# Altium schematic sheet 5-W5500.SchDoc(5-W5500)
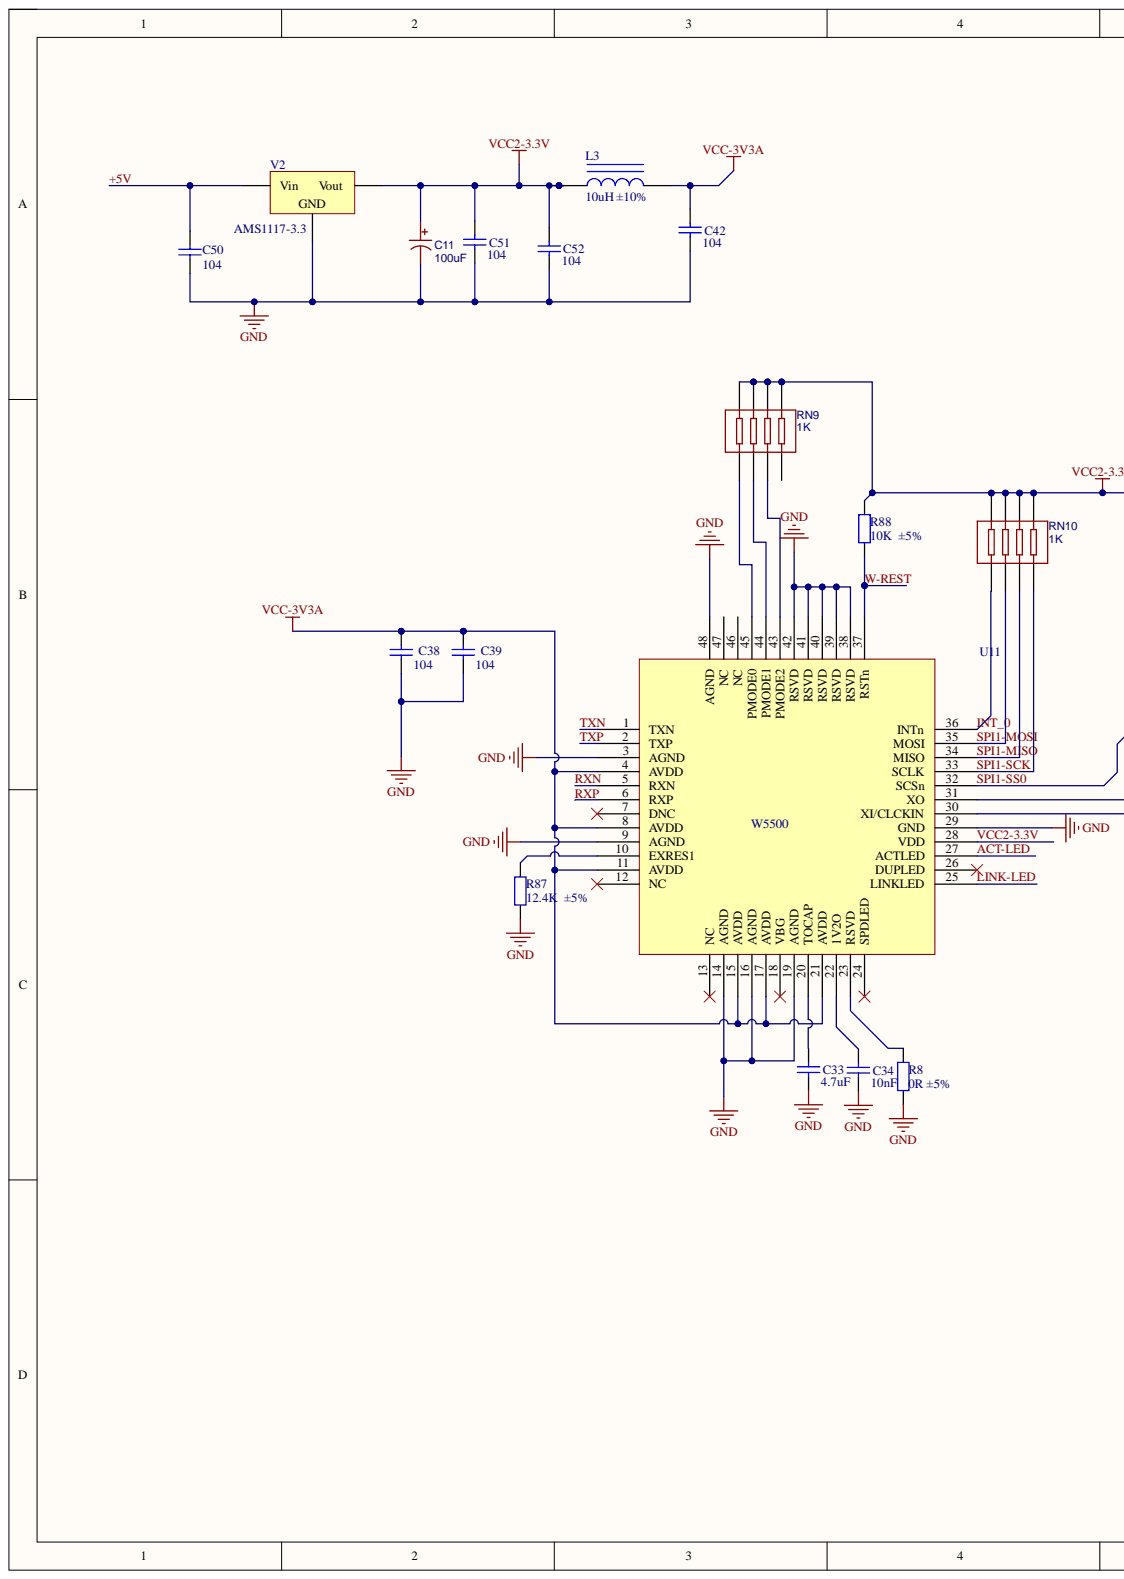
[diagram p0: 5-W5500.SchDoc, left]
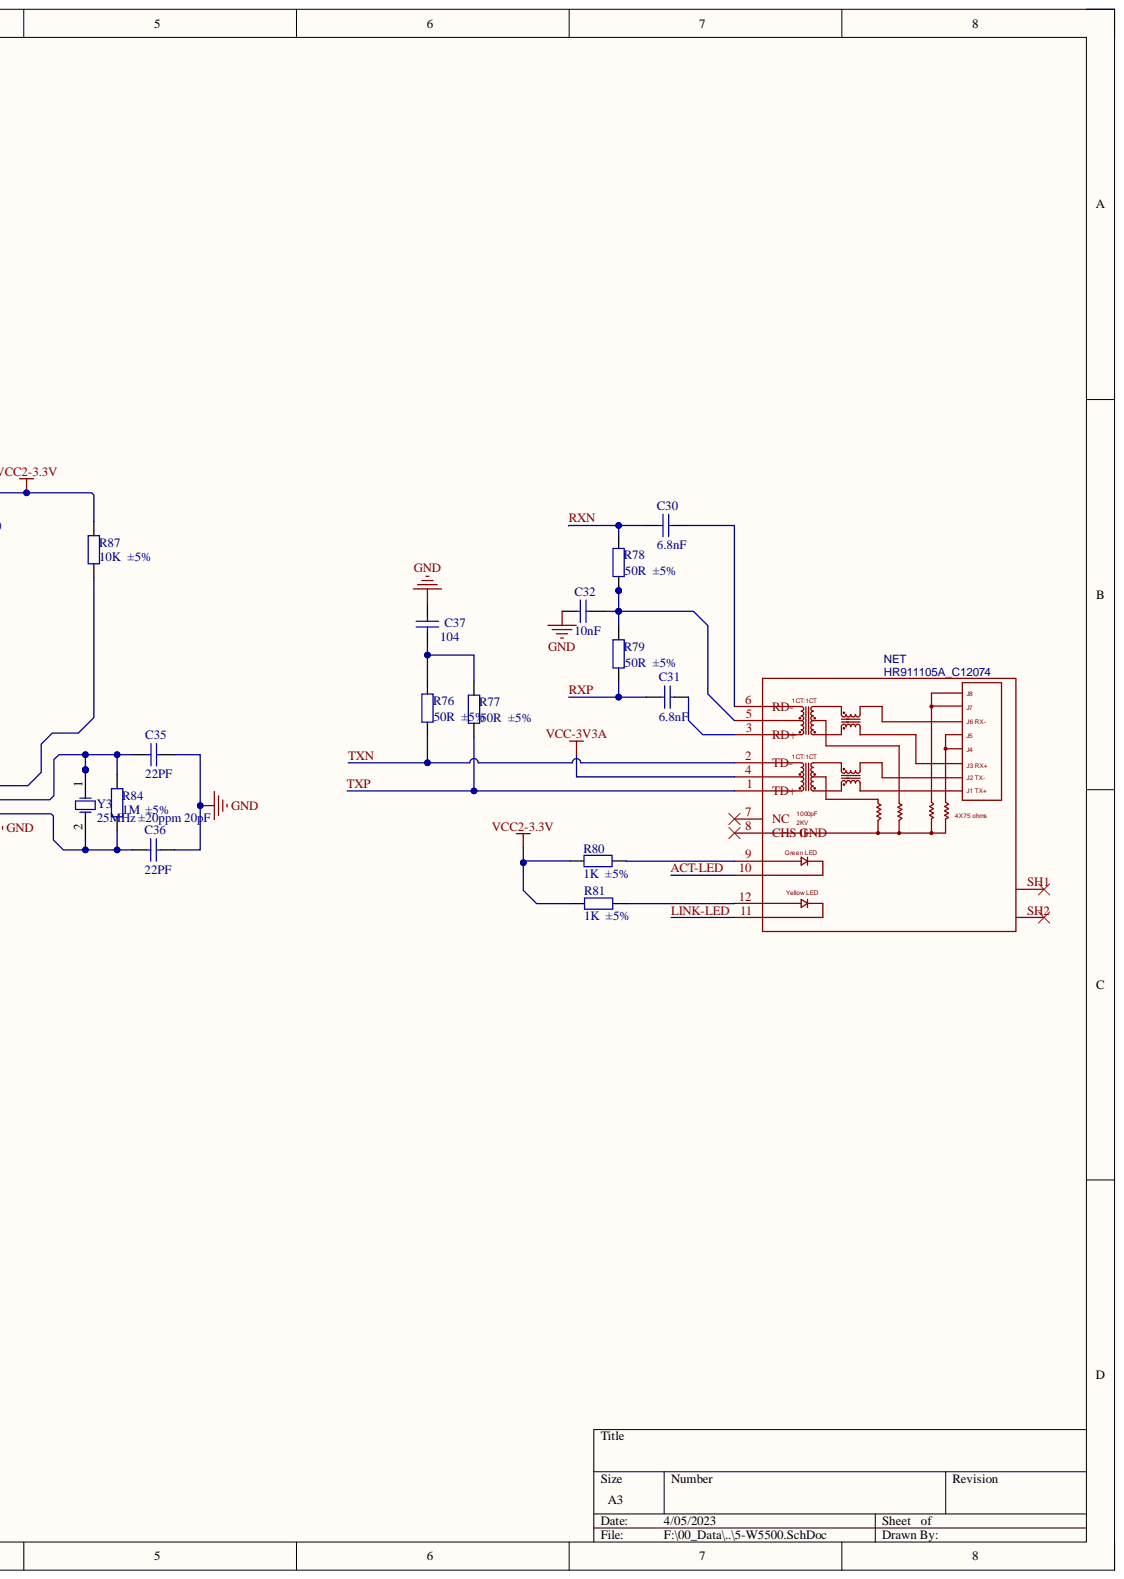
[diagram p1: 5-W5500.SchDoc, right]

## Parts
C11  100uF  [CAP-SMD_BD6.3-L6.6-W6.6-FD]
C30  6.8nF  [0805_C]
C31  6.8nF  [0805_C]
C32  10nF  [0805_C]
C33  4.7uF  [0805_C]
C34  10nF  [0805_C]
C35  22PF  [0805_C]
C36  22PF  [0805_C]
C37  104  [0805_C]
C38  104  [0805_C]
C39  104  [0805_C]
C42  104  [0805_C]
C50  104  [0805_C]
C51  104  [0805_C]
C52  104  [0805_C]
L3  10uH ±10%  [1206_L]
NET  HR911105A_C12074  [RJ45-TH_HR911105A]
R8  0R ±5%  [0603_R]
R76  50R  ±5%  [0603_R]
R77  50R  ±5%  [0603_R]
R78  50R  ±5%  [0603_R]
R79  50R  ±5%  [0603_R]
R80  1K  ±5%  [0603_R]
R81  1K  ±5%  [0603_R]
R84  1M  ±5%  [0603_R]
R87  10K  ±5%  [0603_R]
R87  12.4K  ±5%  [0603_R]  (value not drawn)
R88  10K  ±5%  [0603_R]
RN9  1K  [RES-ARRAY-SMD_0603-8P-L3.2-W1.6-BL]
RN10  1K  [RES-ARRAY-SMD_0603-8P-L3.2-W1.6-BL]
U11  W5500  [LQFP-48_7x7x05P]
V2  AMS1117-3.3  [SOT-223]
Y3  25MHz ±20ppm 20pF  [SMD-5032_2P]

## Nets
GND: C11.2 C32.1 C33.1 C34.1 C35.2 C36.2 C37.1 C38.2 C39.2 C42.1 C50.1 C51.1 C52.1 R8.2 R87.2 U11.3(AGND) U11.9(AGND) U11.14(AGND) U11.16(AGND) U11.19(AGND) U11.29(GND) U11.38(RSVD) U11.39(RSVD) U11.40(RSVD) U11.41(RSVD) U11.42(RSVD) U11.48(AGND) V2.1
VCC-3V3A: C38.1 C39.1 C42.2 L3.2 NET.4 U11.4(AVDD) U11.8(AVDD) U11.11(AVDD) U11.15(AVDD) U11.17(AVDD) U11.21(AVDD)
+5V: C50.2 V2.3
ACT-LED: NET.10 U11.27(ACTLED)
INT_0: RN10.5 U11.36(INTn)
LINK-LED: NET.11 U11.25(LINKLED)
RXN: C30.1 R78.2 U11.5(RXN)
RXP: C31.2 R79.1 U11.6(RXP)
SPI1-MISO: RN10.7 U11.34(MISO)
SPI1-MOSI: RN10.6 U11.35(MOSI)
SPI1-SCK: RN10.8 U11.33(SCLK)
SPI1-SS0: R87.2 U11.32(SCSn)
TXN: NET.2(TD-) R76.1 U11.1(TXN)
TXP: NET.1(TD+) R77.1 U11.2(TXP)
VCC2-3.3V: C11.1 C51.2 C52.2 L3.1 R80.2 R81.2 R87.1 R88.1 RN9.1 RN9.2 RN9.3 RN9.4 RN10.1 RN10.2 RN10.3 RN10.4 U11.28(VDD) V2.2
W-REST: R88.2 U11.37(RSTn)
NetC30_2: C30.2 NET.6(RD-)
NetC31_1: C31.1 NET.3(RD+)
NetC32_2: C32.2 NET.5 R78.1 R79.2
NetC33_2: C33.2 U11.20(TOCAP)
NetC34_2: C34.2 U11.22(1V2O)
NetC35_1: C35.1 R84.1 U11.31(XO) Y3.1
NetC36_1: C36.1 R84.2 U11.30(XI/CLCKIN) Y3.2
NetC37_2: C37.2 R76.2 R77.2
NetNET_9: NET.9 R80.1
NetNET_12: NET.12 R81.1
NetR8_1: R8.1 U11.23(RSVD)
NetR87_1: R87.1 U11.10(EXRES1)
NetRN9_5: RN9.5 U11.45(PMODE0)
NetRN9_6: RN9.6 U11.44(PMODE1)
NetRN9_7: RN9.7 U11.43(PMODE2)

Not connected: NET 7(NC) 8(CHS_GND) SH1 SH2; RN9 8; U11 7(DNC) 12(NC) 13(NC) 18(VBG) 24(SPDLED) 26(DUPLED) 46(NC) 47(NC)

## Library data of the parts
C11 = 100uF: BOM_Manufacturer: ROQANG; BOM_Manufacturer Part: RVT1E101M0607; nameAlias: Capacitance; BOM_Supplier: LCSC; BOM_Supplier Part: C72477; Component Kind: Standard; JLCPCB Part Class: Extended Part; spicePre: C; spiceSymbolName: RVT1E101M0607-C72477
C30-C34 C37-C39 C42 C50-C52 = Cap_0805: 0805贴片电容; Component Kind: Standard; Library Name: 02-Miscellaneous_Devices_ZSF_Library.IntLib; Package: 0805; 常见贴片电容尺寸图: https://wenku.baidu.com/view/21d5206100f69e3143323968011ca300a6c3f6b2.html
  C30 C31: Value: 6.8nF
  C32 C34: Value: 10nF
  C33: Value: 4.7uF
  C37-C39 C42 C50-C52: Value: 104
C35 C36 = Cap_0805: 0805贴片电容; Value: 104; Component Kind: Standard; Library Name: 02-Miscellaneous_Devices_ZSF_Library.IntLib; Package: 0805; 常见贴片电容尺寸图: https://wenku.baidu.com/view/21d5206100f69e3143323968011ca300a6c3f6b2.html
L3 = 1206 10uH 10%: 贴片电感; Component Kind: Standard; ComponentLink1Description: 供应商链接; ComponentLink1URL: http://www.szlcsc.com/product/details_1403.html; Library Name: 02-Miscellaneous_Devices_ZSF_Library.IntLib; Package: 1206; Supplier: LC; SuppliersPartNumber: C1051
NET = HR911105A_C12074: BOM_Manufacturer: HANRUN; BOM_JLCPCB Part Class: Extended Part; BOM_Manufacturer Part: HR911105A; BOM_Supplier: LCSC; BOM_Supplier Part: C12074; Component Kind: Standard; spicePre: J; spiceSymbolName: HR911105A_C12074
R8 R76-R81 R84 R87 R87 R88 = Res_0603: 贴片电阻; Component Kind: Standard; ComponentLink1Description: 供应商链接; ComponentLink1URL: http://www.szlcsc.com/product/details_21903.html; Library Name: 02-Miscellaneous_Devices_ZSF_Library.IntLib; Package: 0603; Supplier: LC; SuppliersPartNumber: C21189
RN9 RN10 = 4.7K: BOM_Manufacturer: Ever Ohms Tech; BOM_Manufacturer Part: CRA034RF4K7P05; Contributor: LCSC; nameAlias: Resistance (Ohms); BOM_JLCPCB Part Class: Extended Part; BOM_Supplier: LCSC; BOM_Supplier Part: C149871; Component Kind: Standard; spicePre: R; spiceSymbolName: CRA034RF4K7P05
U11 = W5500: 以太网; Component Kind: Standard; ComponentLink1Description: 供应商链接; ComponentLink1URL: http://www.szlcsc.com/product/details_33808.html; Library Name: 02-Miscellaneous_Devices_ZSF_Library.IntLib; Package: LQFP-48_7x7x05P; Supplier: LC; SuppliersPartNumber: C32843
V2 = AMS1117-3.3 SOT-223: 低压差线性稳压(LDO); Component Kind: Standard; ComponentLink1Description: 供应商链接; ComponentLink1URL: http://www.szlcsc.com/product/details_6652.html; Library Name: 02-Miscellaneous_Devices_ZSF_Library.IntLib; Package: SOT-223; Supplier: LC; SuppliersPartNumber: C6186
Y3 = SMD-5032_2P 8MHz 20ppm 20pF: 贴片晶振; Component Kind: Standard; ComponentLink1Description: 供应商链接; ComponentLink1URL: http://www.szlcsc.com/product/details_19261.html; Library Name: 02-Miscellaneous_Devices_ZSF_Library.IntLib; Package: SMD-5032_2P; Supplier: LC; SuppliersPartNumber: C18560
